# Altium schematic sheet FibreReceiver_3c_Input1.SchDoc
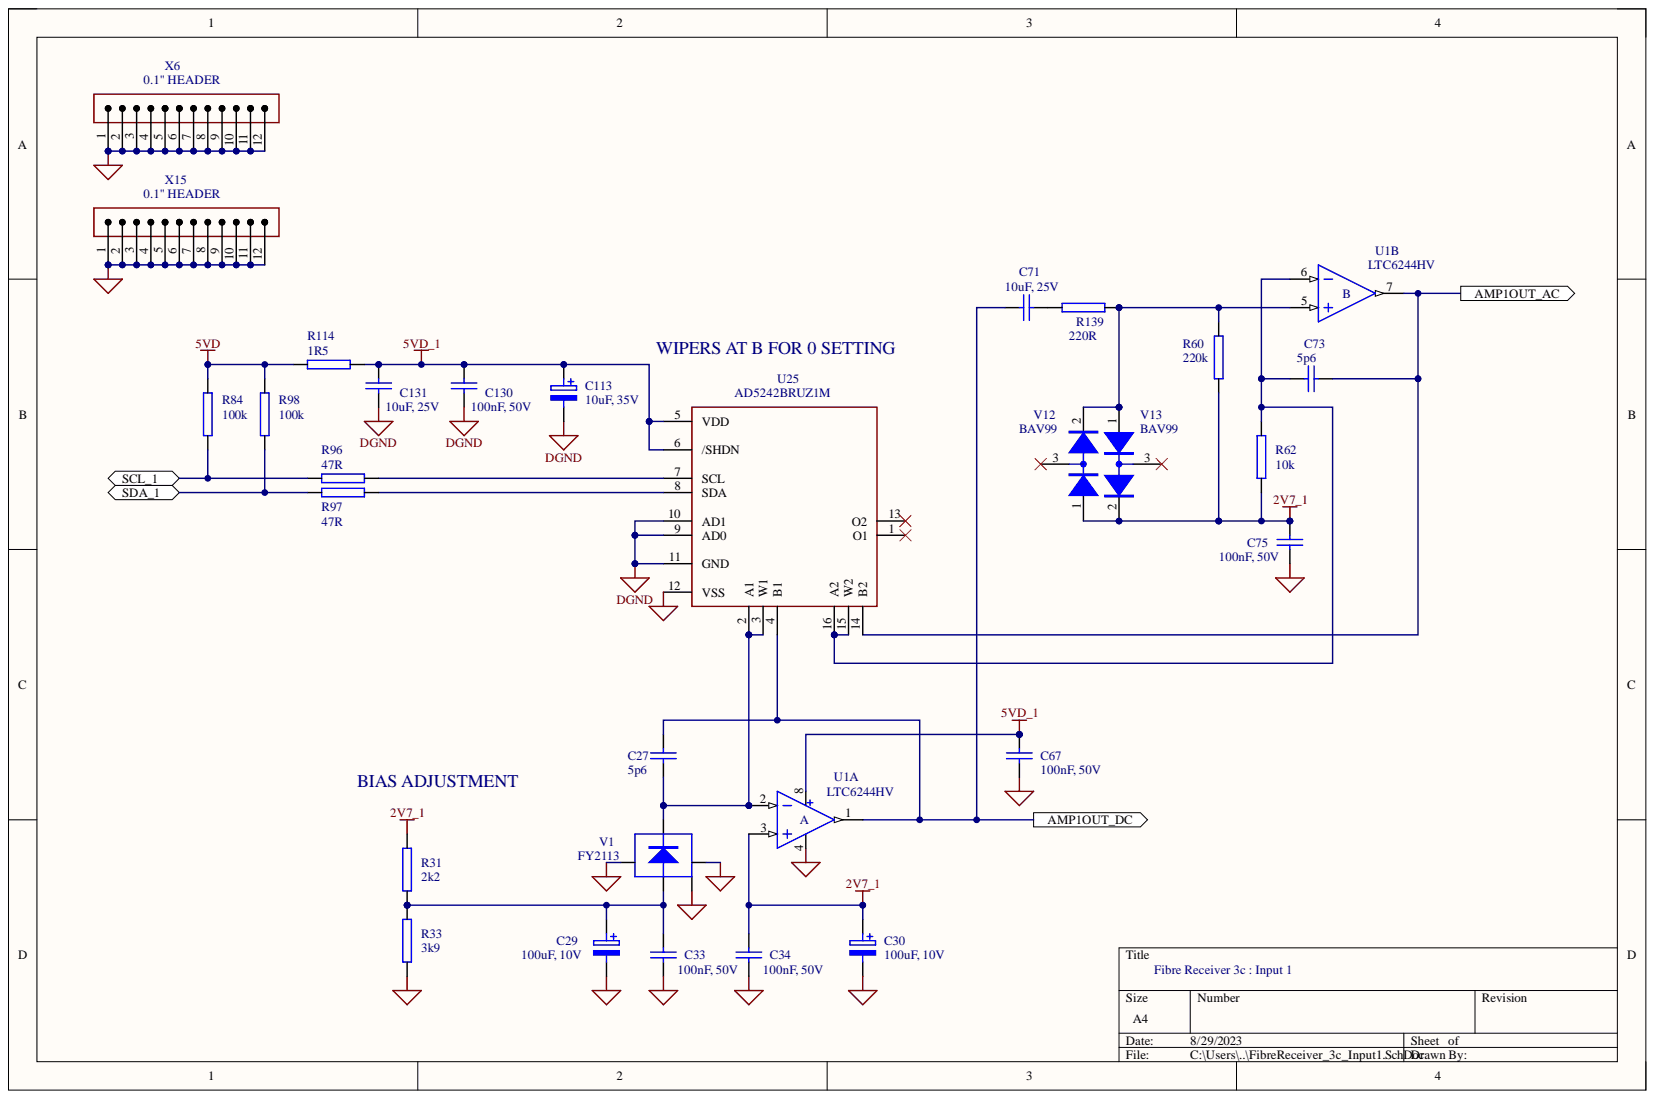
[diagram p0: FibreReceiver_3c_Input1.SchDoc]

## Parts
C27  5p6  [CAP0603]
C29  100uF, 10V  [CAP2312]
C30  100uF, 10V  [CAP2312]
C33  100nF, 50V  [CAP0603]
C34  100nF, 50V  [CAP0603]
C67  100nF, 50V  [CAP0603]
C71  10uF, 25V  [CAP1206]
C73  5p6  [CAP0603]
C75  100nF, 50V  [CAP0603]
C113  10uF, 35V  [CAP2312]
C130  100nF, 50V  [CAP0603]
C131  10uF, 25V  [CAP1206]
R31  2k2  [RES0603]
R33  3k9  [RES0603]
R60  220k  [RES0603]
R62  10k  [RES0603]
R84  100k  [RES0603]
R96  47R  [RES0603]
R97  47R  [RES0603]
R98  100k  [RES0603]
R114  1R5  [RES0603]
R139  220R  [RES0603]
U1  LTC6244HV  [MSOP8]  (parts A,B)
U25  AD5242BRUZ1M  [TSSOP16]
V1  FY2113  [DIODE_PD_A_FLYIN]
V12  BAV99  [SOT23]
V13  BAV99  [SOT23]
X6  0.1" HEADER  [CONTH1X12VM100HDR]
X15  0.1" HEADER  [CONTH1X12VM100HDR]

## Ports
AMP1OUT_AC, AMP1OUT_DC, SCL_1, SDA_1

## Nets
2V7_1: C30.1 C34.1 C75.1 R31.1 R60.2 R62.1 U1.3 V12.1 V13.2
5VD: R84.1 R98.1 R114.1
5VD_1: C67.1 C113.1 C130.1 C131.2 R114.2 U1.8 U25.5(VDD) U25.6(/SHDN)
DGND: C113.2 C130.2 C131.1 U25.9(AD0) U25.10(AD1) U25.11(GND)
GND: C29.2 C30.2 C33.2 C34.2 C67.2 C75.2 R33.2 U1.4(A) U25.12(VSS) V1.0a V1.0b V1.3 X6.1 X6.2 X6.3 X6.4 X6.5 X6.6 X6.7 X6.8 X6.9 X6.10 X6.11 X6.12 X15.1 X15.2 X15.3 X15.4 X15.5 X15.6 X15.7 X15.8 X15.9 X15.10 X15.11 X15.12
AMP1OUT_AC: C73.2 U1.7(B) U25.14(B2) ; port AMP1OUT_AC
AMP1OUT_DC: C27.1 C71.1 U1.1 U25.4(B1) ; port AMP1OUT_DC
SCL_1: R84.2 R96.1 ; port SCL_1
SDA_1: R97.1 R98.2 ; port SDA_1
NetC27_2: C27.2 U1.2 U25.2(A1) U25.3(W1) V1.2
NetC29_1: C29.1 C33.1 R31.2 R33.1 V1.1
NetC71_2: C71.2 R139.1
NetC73_1: C73.1 R62.2 U1.6 U25.15(W2) U25.16(A2)
NetR60_1: R60.1 R139.2 U1.5 V12.2 V13.1
NetR96_2: R96.2 U25.7(SCL)
NetR97_2: R97.2 U25.8(SDA)

Not connected: U25 1(O1) 13(O2); V12 3; V13 3

## Library data of the parts
C27 C73 = CAP SMD 5.6PF 50V CAP0603: CAP SMD 0603 5.6pF +/-5% 50V NPO; Manuf: Murata; Manuf Part Num: GRM1885C1H5R6DA01D; Component Kind: Standard; Supplier 1: Mouser; Supplier 1 Part Num: 81-GRM1885C1H5R6DA1D; Supplier 2: element14; Supplier 2 Part Num: 249-4221; Library Name: BinopiSymbols_mn.SchLIB
C29 C30 = CAP TANT 100UF 10V CAP2312: CAP TANT 100uF 10% 10V; Manuf: AVX; Manuf Part Num: TAJC107K010RNJ; Component Kind: Standard; Supplier 1: element14; Supplier 1 Part Num: 197-180; Supplier 2: DigiKey; Supplier 2 Part Num: 478-1704-1-ND; Library Name: BinopiSymbols_mn.SchLIB
C33 C34 C67 C75 C130 = CAP SMD 100NF 50V CAP0603: CAP SMD 0603 100nF +/-10% 50V X7R; Manuf: Kemet; Manuf Part Num: C0603C104K5RACTU; Component Kind: Standard; Supplier 1: element14; Supplier 1 Part Num: 128-8255; Supplier 2: DigiKey; Supplier 2 Part Num: 399-5089-1-ND; Library Name: BinopiSymbols_mn.SchLIB
C71 C131 = CAP SMD 10UF 25V CAP1206: CAP SMD 1206 10uF +/-10% 25V X5R; Manuf: Murata; Manuf Part Num: GRM319R61E106KA12D; Component Kind: Standard; Supplier 1: Digikey; Supplier 1 Part Num: 490-5525-1-ND; Supplier 2: element14; Supplier 2 Part Num: 184-5759; Library Name: BinopiSymbols_mn.SchLIB
C113 = CAP TANT 10UF 35V KEMET CAP2312: CAP TANT 10uF 10% 35V 1.6Ohm; Manuf: KEMET; Manuf Part Num: T491C106K035AT; Taggle P/N: tpn?; Component Kind: Standard; Supplier1 Part Number: 130-7841; Supplier2 Part Number: 399-8333-1-ND; Supplier 1: FARNELL; Supplier 2: Digi-Key; tol: 10%; value: 10uF; voltage: 35V; Library Name: BinopiSymbols_mn.SchLIB
R31 = RES 2K2 RES0603: RES SMD 0603 2k2 1% 0.1W; Manuf: Yageo; Manuf Part Num: RC0603FR-072K2L; Component Kind: Standard; Supplier 1: Digikey; Supplier 1 Part Num: 311-2.20KHRTR-ND; Library Name: BinopiSymbols_mn.SchLIB
R33 = RES 3K9 RES0603: RES SMD 0603 3K9 1% 0.1W; Manuf: YAGEO; Manuf Part Num: RC0603FR-073K9L; Component Kind: Standard; Supplier 1: Digikey; Supplier 1 Part Num: 311-3.90KHRCT-ND; Supplier 2: element14; Supplier 2 Part Num: 214-3821; Library Name: BinopiSymbols_mn.SchLIB
R60 = RES 220K RES0603: RES SMD 0603 220k 1% 0.1W; Manuf: Yageo; Manuf Part Num: RC0603FR-07220KL; Component Kind: Standard; Supplier 1: Digi-Key; Supplier Part Number 1: 311-220KHRTR-ND; Library Name: Binopi Symbols.SchLIB
R62 = RES 10K RES0603: RES SMD 0603 10K 1% 0.1W; Manuf: Yageo; Manuf Part Num: RC0603FR-0710KL; Component Kind: Standard; Supplier 1: Digikey; Supplier 1 Part Num: 311-10.0KHRTR-ND; Supplier 2: element14; Supplier 2 Part Num: 213-3286; Library Name: BinopiSymbols_mn.SchLIB
R84 R98 = RES 100K RES0603: RES SMD 0603 100k 1% 0.1W; Manuf: Yageo; Manuf Part Num: RC0603FR-07100KL; Component Kind: Standard; Supplier 1: Digikey; Supplier 1 Part Num: 311-100KHRCT-ND; Supplier 2: element14; Supplier 2 Part Num: 923-8727; Library Name: BinopiSymbols_mn.SchLIB
R96 R97 = RES 47R RES0603: RES SMD 0603 47R 1% 0.063W; Manuf: Vishay / Dale; Manuf Part Num: CRCW060347R0FKEA; Component Kind: Standard; Supplier 1: Mouser; Supplier 1 Part Num: 71-CRCW0603-47-E3; Supplier 2: DigiKey; Supplier 2 Part Num: 541-47.0HCT-ND; Library Name: BinopiSymbols_mn.SchLIB
R114 = RES 1R5 RES0603: RES SMD 0603 1R5 5% 0.1W; Manuf: Yageo; Manuf Part Num: RC0603FR-071R5L; Component Kind: Standard; Supplier: element14; Supplier Part Num: 923-8140; Library Name: BinopiSymbols_mn.SchLIB
R139 = RES 220R RES0603: RES SMD 0603 220R 1% 0.05W; Manuf: Yageo; Manuf Part Num: RC0201FR-07220RL; Component Kind: Standard; Supplier 1: element14; Supplier 1 Part Num: 923-8409; Supplier 2: Mouser; Supplier 2 Part Num: 603-RC0603FR-07220RL; Library Name: BinopiSymbols_mn.SchLIB
U1 = IC LTC6244HV: IC-OPAMP LTC6244HV LowNse Dual 50MHz; Manuf: Linear Technology; Manuf Part Num: LTC6244HVCMS8#PBF; Component Kind: Standard; Supplier 1: element14; Supplier 1 Part Num: 229-4751; Supplier 2: DigiKey; Supplier 2 Part Num: LTC6244HVCMS8#PBF-ND; Library Name: BinopiSymbols_mn.SchLIB
U25 = IC AD5242BRUZ1M 2X1M DIGITAL POT: AD5242BRUZ1M 2x1M Digital Pot; Manuf: Analog Devices; Component Kind: Standard; Manuf Part Num: AD5242BRUZ1M; Supplier 1: DigiKey; Supplier 1 Part Num: AD5242BRUZ1M-ND; Supplier 2: element14; Supplier 2 Part Num: 231-7275; Library Name: BinopiSymbols_mn.SchLIB
V1 = DIODE PHOTO 3GHz FY2113-007-S-0-Null: Diode Photo 3GHz Flyin; Component Kind: Standard; Manuf: Flyin; Manuf Part Num: FY2113-007-S-0-Null; Library Name: BinopiSymbols_mn.SchLIB
V12 V13 = DIODE 2X BAV99 SOT23: High Speed Double Diode   SMD  SOT23; Manuf: ON Semiconductor; Manuf Part Num: BAV99LT3G; Component Kind: Standard; Supplier 1: DigiKey; Supplier 1 Part Num: BAV99LT3GOSCT-ND; Supplier 2: RS; Supplier 2 Part Num: 690-0016; Supplier 3: Mouser; Supplier 3 Part Num: 863-BAV99LT3G; Library Name: BinopiSymbols_mn.SchLIB
X6 X15 = CONN 12 PIN 0.1" VERTICAL HEADER: 12 Pin Header 0.1" (0.2.54mm) Wire to Board; Manuf: Wurth; Manuf Part Num: 61301211121; Component Kind: Standard; Supplier 1: element14; Supplier 1 Part Num: 235-6162; Supplier 2: DigiKey; Supplier 2 Part Num: 732-5323-ND; Library Name: BinopiSymbols_mn.SchLIB
